# Altium schematic sheet Sheet1.SchDoc(Sheet1)
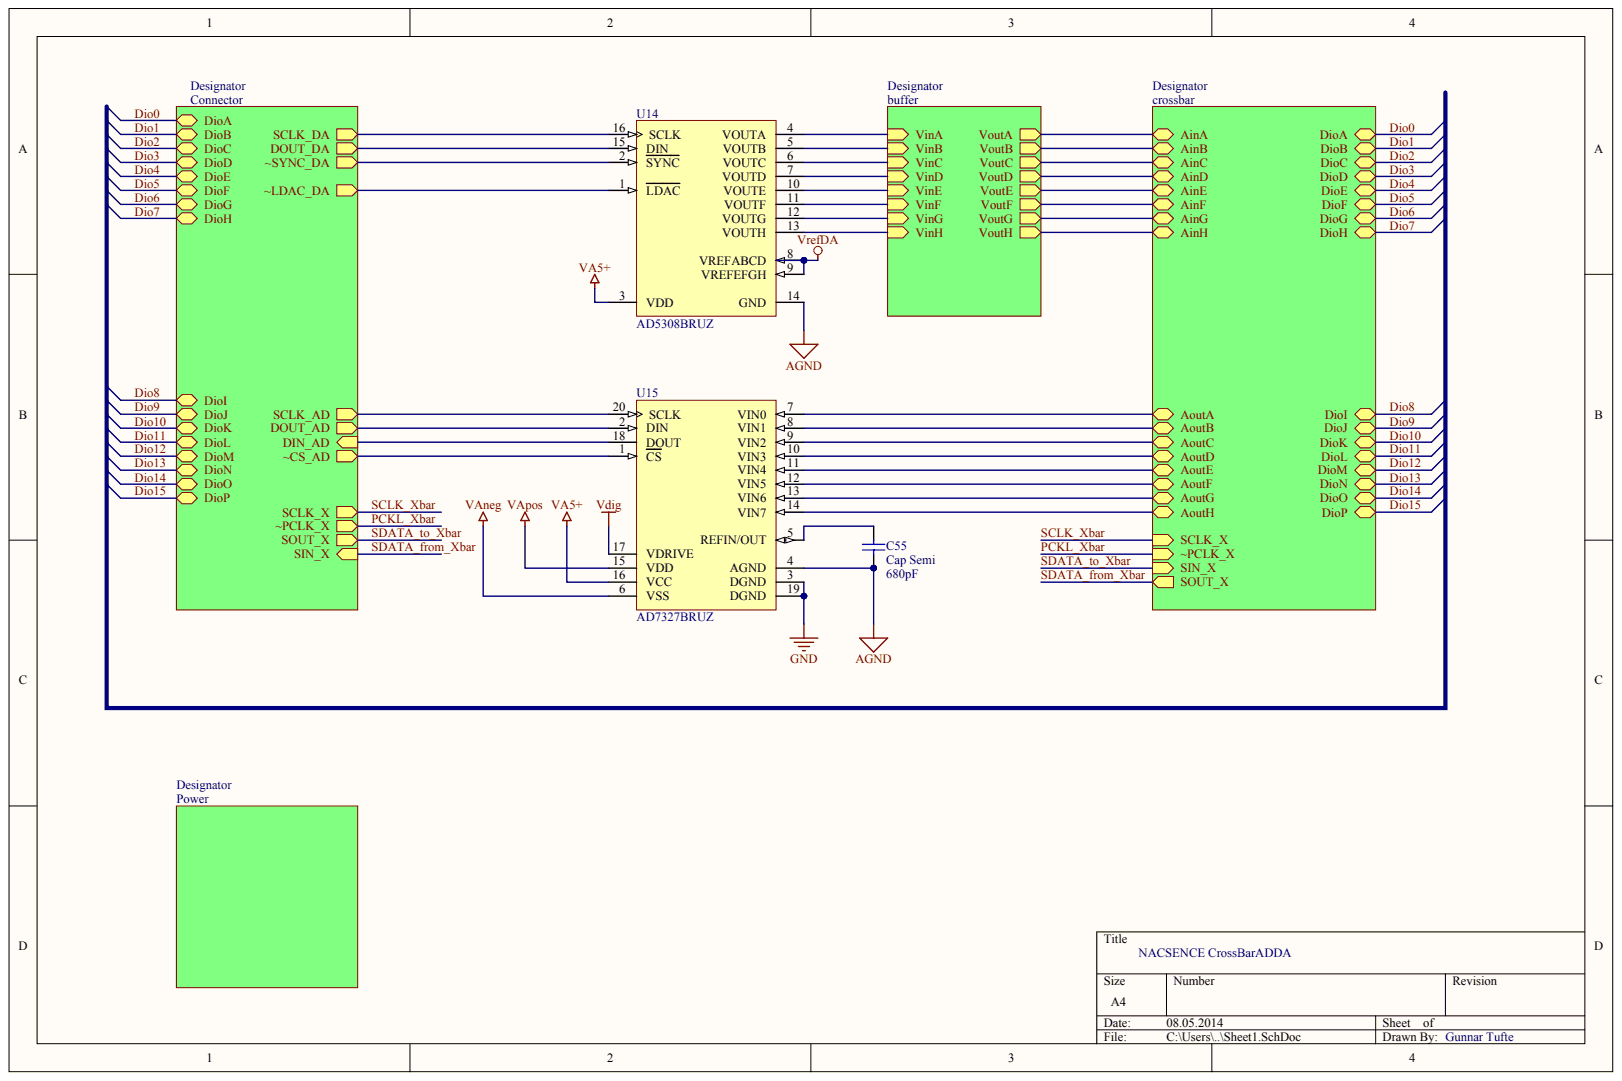
[diagram p0: Sheet1.SchDoc]

## Parts
C55  Cap Semi  value 680pF
U14  AD5308BRUZ
U15  AD7327BRUZ

## Nets
AGND: C55.2 U14.14(GND) U15.4(AGND)
GND: U15.3(DGND) U15.19(DGND)
VA5+: U14.3(VDD) U15.16(VCC)
VANEG: U15.6(VSS)
VAPOS: U15.15(VDD)
VDIG: U15.17(VDRIVE)
VREFDA: U14.8(VREFABCD) U14.9(VREFEFGH)
NetC55_1: C55.1 U15.5(REFIN/OUT)
NetU14_1: U14.1(LDAC)
NetU14_2: U14.2(SYNC)
NetU14_4: U14.4(VOUTA)
NetU14_5: U14.5(VOUTB)
NetU14_6: U14.6(VOUTC)
NetU14_7: U14.7(VOUTD)
NetU14_10: U14.10(VOUTE)
NetU14_11: U14.11(VOUTF)
NetU14_12: U14.12(VOUTG)
NetU14_13: U14.13(VOUTH)
NetU14_15: U14.15(DIN)
NetU14_16: U14.16(SCLK)
NetU15_1: U15.1(CS)
NetU15_2: U15.2(DIN)
NetU15_7: U15.7(VIN0)
NetU15_8: U15.8(VIN1)
NetU15_9: U15.9(VIN2)
NetU15_10: U15.10(VIN3)
NetU15_11: U15.11(VIN4)
NetU15_12: U15.12(VIN5)
NetU15_13: U15.13(VIN6)
NetU15_14: U15.14(VIN7)
NetU15_18: U15.18(DOUT)
NetU15_20: U15.20(SCLK)
AINA:
AINB:
AINC:
AIND:
AINE:
AINF:
AING:
AINH:
DIO0:
DIO1:
DIO2:
DIO3:
DIO4:
DIO5:
DIO6:
DIO7:
DIO8:
DIO9:
DIO10:
DIO11:
DIO12:
DIO13:
DIO14:
DIO15:
N00323:
PCKL_XBAR:
SCLK_XBAR:
SDATA_FROM_XBAR:
SDATA_TO_XBAR:

## Library data of the parts
U14 = (no symbol name): ADI Part Number: AD5308; Manufacturer: Analog Devices; # DAC Outputs: 8; Max V+: 5.5V; Op Pwr Diss: 5mW; Packing: Tube; Settling Time: 6µs; Single Supply: Yes; DatasheetVersion: Rev.F; PackageVersion: Nov-2002; PackageReference: RU-16; PackageDescription: 16-Lead Thin Shrink Small Outline Package [TSSOP]; Resolution (Bits): 8; RoHS: Y; Mounting Technology: Surface Mount; Code_JEDEC: MO-153-AB; ComponentLink1Description: Manufacturer URL; ComponentLink1URL: http://www.analog.com/en/index.html; ComponentLink2Description: Datasheet; ComponentLink2URL: http://www.analog.com/static/imported-files/data_sheets/AD5308_5318_5328.pdf; ComponentLink3Description: Package Specification; ComponentLink3URL: http://www.analog.com/static/imported-files/packages/36244347913743854010197452789RU16.pdf; ComponentLink4Description: URL Link1; ComponentLink4URL: Product URL:http://www.analog.com/en/digital-to-analog-converters/da-converters/ad5308/products/product.html
U15 = (no symbol name): Part Number: AD7327; Manufacturer: Analog Devices; Resolution (Bits): 13; Channels: 8; Throughput Rate (SPS): 500K; Interface: Ser, SPI; Packing: Tube; DatasheetVersion: Rev.A; PackageVersion: 2000; PackageReference: RU-20; PackageDescription: 20-Lead Thin Shrink Small Outline Package [TSSOP]; RoHS: Y; Mounting Technology: Surface Mount; Code_JEDEC: MO-153AC; ComponentLink1Description: Manufacturer URL; ComponentLink1URL: http://www.analog.com/en/index.html; ComponentLink2Description: Datasheet; ComponentLink2URL: http://www.analog.com/static/imported-files/data_sheets/AD7327.pdf; ComponentLink3Description: Package Specification; ComponentLink3URL: http://www.analog.com/static/imported-files/packages/364515793657813558433715854054607941441RU20.pdf; ComponentLink4Description: Product URL; ComponentLink4URL: http://www.analog.com/en/analog-to-digital-converters/ad-converters/ad7327/products/product.html
C55 = (no symbol name): Published: 8-Jun-2000; LatestRevisionDate: 29-May-2009; LatestRevisionNote: IPC-7351 Footprint Added.; PackageReference: C1206; PackageDescription: Chip Capacitor; Publisher: Altium Limited
